# Altium schematic sheet MID_AR0234.SchDoc(U_BC2077__MID_AR0234)
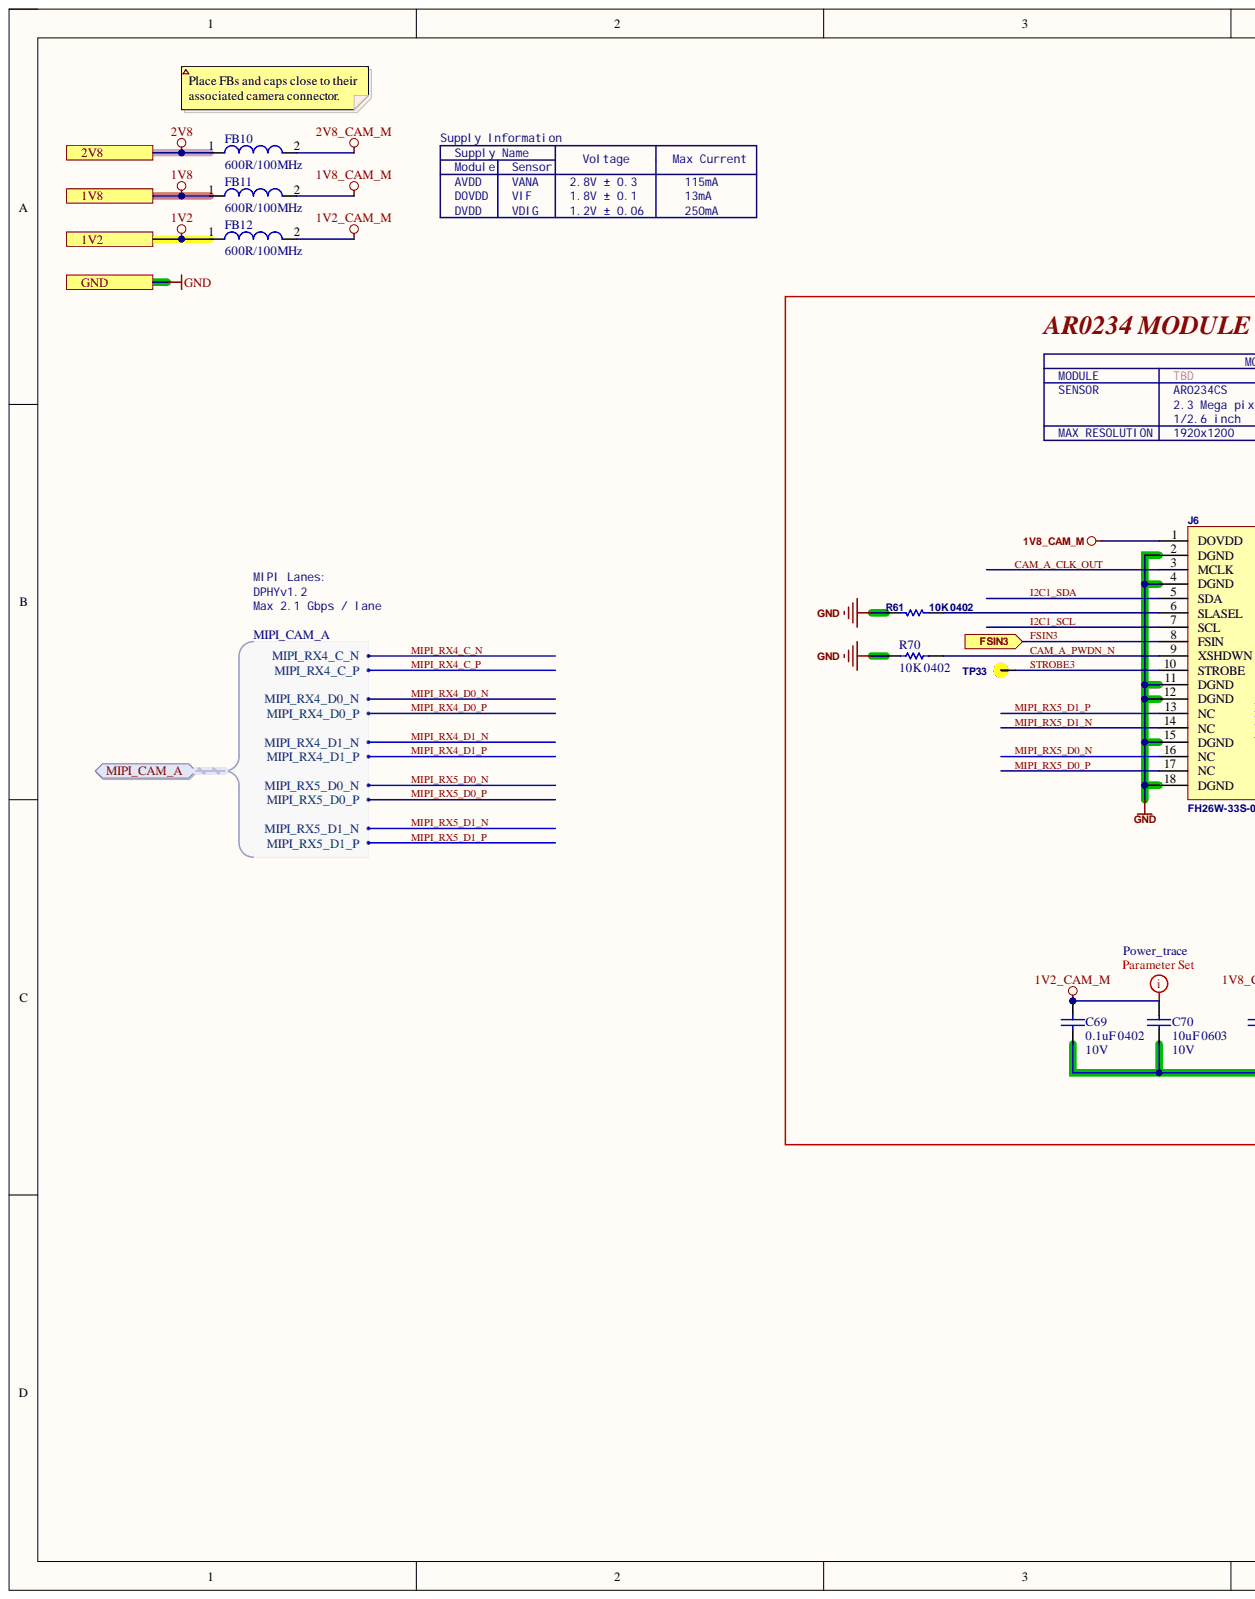
[diagram p0: MID_AR0234.SchDoc, left]
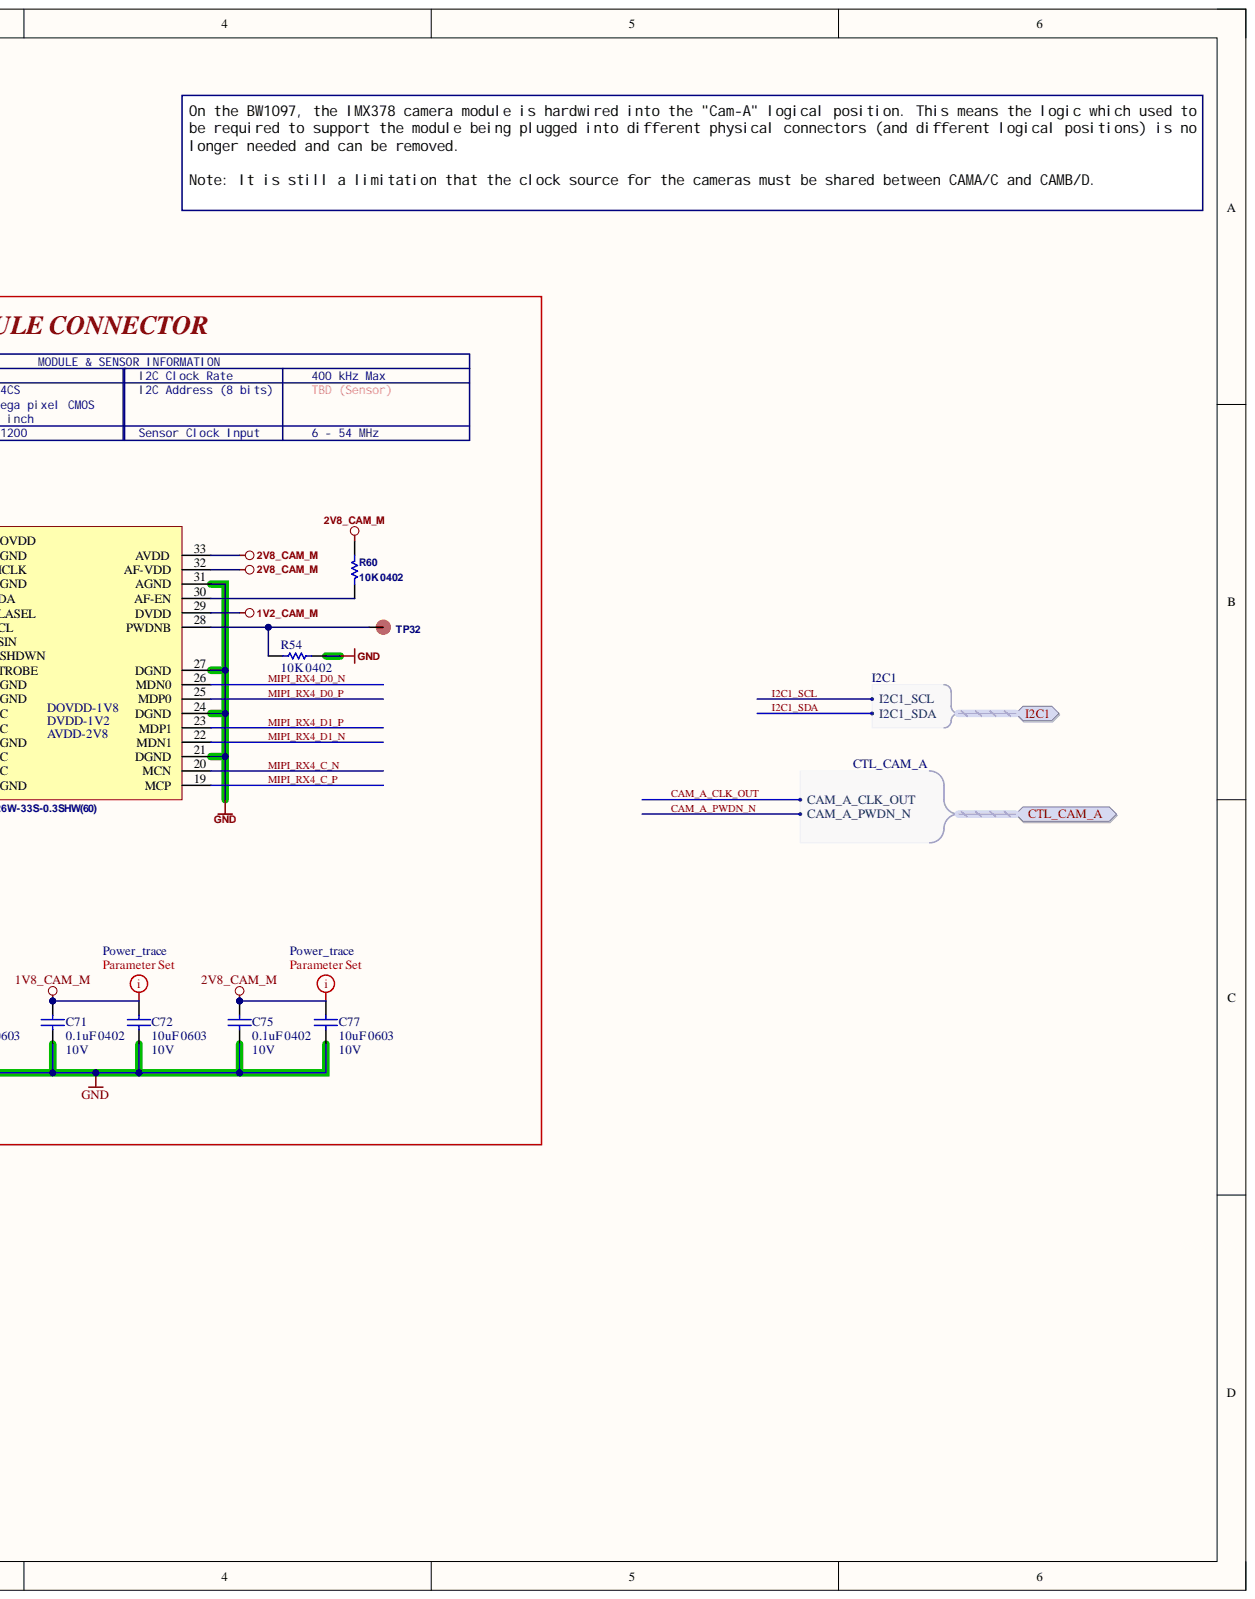
[diagram p1: MID_AR0234.SchDoc, right]

## Parts
C69  0.1uF 0402  Voltage Rating: 10V  [CAPC0402(1005)70_L]
C70  10uF 0603  Capacitance: 10uF  Case Code (Imperial): 0603  Voltage Rating: 10V  [CAPC0603(1608)100_L]
C71  0.1uF 0402  Voltage Rating: 10V  [CAPC0402(1005)70_L]
C72  10uF 0603  Capacitance: 10uF  Case Code (Imperial): 0603  Voltage Rating: 10V  [CAPC0603(1608)100_L]
C75  0.1uF 0402  Voltage Rating: 10V  [CAPC0402(1005)70_L]
C77  10uF 0603  Capacitance: 10uF  Case Code (Imperial): 0603  Voltage Rating: 10V  [CAPC0603(1608)100_L]
FB10  600R/100MHz  [2508056017Y2]
FB11  600R/100MHz  [2508056017Y2]
FB12  600R/100MHz  [2508056017Y2]
J6  FH26W-33S-0.3SHW(60)  [AC-PY003-OV9282]
R54  10K 0402  Case Code (Imperial): 0402  [RESC0402(1005)_L]
R60  10K 0402  Case Code (Imperial): 0402  [RESC0402(1005)_L]
R61  10K 0402  Case Code (Imperial): 0402  [RESC0402(1005)_L]
R70  10K 0402  Case Code (Imperial): 0402  [RESC0402(1005)_L]
TP32  TP100_200_NS  [TP100_200_NS]  (value not drawn)  (no BOM)
TP33  TP100_200_NS  [TP100_200_NS]  (value not drawn)  (no BOM)

## Ports
1V2, 1V8, 2V8, CTL_CAM_A, CTL_CAM_A.CAM_A_CLK_OUT, CTL_CAM_A.CAM_A_PWDN_N, FSIN3, GND, I2C1, I2C1.I2C1_SCL, I2C1.I2C1_SDA, MIPI_CAM_A, MIPI_CAM_A.MIPI_RX4_C_N, MIPI_CAM_A.MIPI_RX4_C_P, MIPI_CAM_A.MIPI_RX4_D0_N, MIPI_CAM_A.MIPI_RX4_D0_P, MIPI_CAM_A.MIPI_RX4_D1_N, MIPI_CAM_A.MIPI_RX4_D1_P, MIPI_CAM_A.MIPI_RX5_D0_N, MIPI_CAM_A.MIPI_RX5_D0_P, MIPI_CAM_A.MIPI_RX5_D1_N, MIPI_CAM_A.MIPI_RX5_D1_P

## Nets
1V2_CAM_M: C69.2 C70.2 FB12.2 J6.29(DVDD)
1V8_CAM_M: C71.2 C72.2 FB11.2 J6.1(DOVDD)
2V8_CAM_M: C75.2 C77.2 FB10.2 J6.32(AF-VDD) J6.33(AVDD) R60.1
1V2: FB12.1 ; port 1V2
1V8: FB11.1 ; port 1V8
2V8: FB10.1 ; port 2V8
CAM_A_CLK_OUT: J6.3(MCLK) ; port CTL_CAM_A
CAM_A_PWDN_N: J6.9(XSHDWN) R70.2 ; port CTL_CAM_A
FSIN3: J6.8(FSIN) ; port FSIN3
GND: C69.1 C70.1 C71.1 C72.1 C75.1 C77.1 J6.2(DGND) J6.4(DGND) J6.11(DGND) J6.12(DGND) J6.15(DGND) J6.18(DGND) J6.21(DGND) J6.24(DGND) J6.27(DGND) J6.31(AGND) R54.2 R61.2 R70.1 ; port GND
I2C1_SCL: J6.7(SCL) ; port I2C1
I2C1_SDA: J6.5(SDA) ; port I2C1
MIPI_RX4_C_N: J6.20(MCN) ; port MIPI_CAM_A
MIPI_RX4_C_P: J6.19(MCP) ; port MIPI_CAM_A
MIPI_RX4_D0_N: J6.26(MDN0) ; port MIPI_CAM_A
MIPI_RX4_D0_P: J6.25(MDP0) ; port MIPI_CAM_A
MIPI_RX4_D1_N: J6.22(MDN1) ; port MIPI_CAM_A
MIPI_RX4_D1_P: J6.23(MDP1) ; port MIPI_CAM_A
MIPI_RX5_D0_N: J6.16(NC) ; port MIPI_CAM_A
MIPI_RX5_D0_P: J6.17(NC) ; port MIPI_CAM_A
MIPI_RX5_D1_N: J6.14(NC) ; port MIPI_CAM_A
MIPI_RX5_D1_P: J6.13(NC) ; port MIPI_CAM_A
STROBE3: J6.10(STROBE) TP33.1
NetJ6_6: J6.6(SLASEL) R61.1
NetJ6_28: J6.28(PWDNB) R54.1 TP32.1
NetJ6_30: J6.30(AF-EN) R60.2

## Library data of the parts
C69 C71 C75 = GRM155R71A104JA01D: CAP CER 0.1UF 10V X7R 0402 5%; Manufacturer 1: Murata; Capacitance: 100nF; Case Code (Imperial): 0402; Case Code (Metric): 1005; Case/Package: 0402; Component Kind: Standard; ComponentLink1Description: https://datasheet.ciiva.com/5411/product-5411135.pdf?src-supplier=Mouser; ComponentLink1URL: https://datasheet.ciiva.com/5411/product-5411135.pdf?src-supplier=Mouser; ComponentLink2Description: https://datasheet.ciiva.com/11618/c02e-11618821.pdf?src-supplier=Avnet; ComponentLink2URL: https://datasheet.ciiva.com/11618/c02e-11618821.pdf?src-supplier=Avnet; Depth: 0.5mm; Dielectric: X7R; Height: 0.5mm; Lead Free: Lead Free; Length: 1mm; Manufacturer Part Number 1: GRM155R71A104JA01D; Max Operating Temperature: 125°C; Min Operating Temperature: -55°C; Mount: Surface Mount; Package Quantity: 10000; Packaging: Tape and Reel; Pricing 1: 1=0.10000, 10=0.02500, 100=0.01130, 500=0.00806, 1000=0.00633, 2500=0.00575, 5000=0.00529 (USD); Radiation Hardening: No; RoHS: Yes; Stock 1: 1346709; Supplier 1: Digi-Key; Supplier Part Number 1: 490-10777-1-ND; Termination: SMD/SMT; Thickness: 0.022inch; Tolerance: 5%; Voltage Rating (DC): 10V; Width: 0.02inch; Library Name: LuxonisMaster.SchLib
C70 C72 C77 = GRM188R61A106ME69D: CAP CER 10UF 10V X5R 0603 20%; Manufacturer 1: Murata; Material: Ceramic; Case Code (Metric): 1608; Case/Package: 0603; Component Kind: Standard; ComponentLink1Description: http://www.mouser.com/ds/2/281/c02e-2905.pdf?src-supplier=Mouser; ComponentLink1URL: http://www.mouser.com/ds/2/281/c02e-2905.pdf?src-supplier=Mouser; ComponentLink2Description: http://components.arrow.com/part/dataview/0323_06119472?src-supplier=Arrow+Europe; ComponentLink2URL: http://components.arrow.com/part/dataview/0323_06119472?src-supplier=Arrow+Europe; ComponentLink3Description: http://www.farnell.com/datasheets/2048014.pdf?src-supplier=Farnell; ComponentLink3URL: http://www.farnell.com/datasheets/2048014.pdf?src-supplier=Farnell; ComponentLink4Description: http://www.farnell.com/datasheets/1872210.pdf?src-supplier=Farnell; ComponentLink4URL: http://www.farnell.com/datasheets/1872210.pdf?src-supplier=Farnell; ComponentLink5Description: http://search.murata.co.jp/Ceramy/image/img/A01X/G101/ENG/GRM188R61A106ME69-01.pdf?src-supplier=Digi-Key; ComponentLink5URL: http://search.murata.co.jp/Ceramy/image/img/A01X/G101/ENG/GRM188R61A106ME69-01.pdf?src-supplier=Digi-Key; ComponentLink6Description: http://www.farnell.com/datasheets/1872210.pdf?src-supplier=Newark; ComponentLink6URL: http://www.farnell.com/datasheets/1872210.pdf?src-supplier=Newark; ComponentLink7Description: http://www.farnell.com/datasheets/2048014.pdf?src-supplier=Element14; ComponentLink7URL: http://www.farnell.com/datasheets/2048014.pdf?src-supplier=Element14; ComponentLink8Description: http://www.farnell.com/datasheets/2048014.pdf?src-supplier=Newark; ComponentLink8URL: http://www.farnell.com/datasheets/2048014.pdf?src-supplier=Newark; Depth: 0.8mm; Dielectric: X5R; Height: 0.8mm; Lead Free: Lead Free; Length: 1.6mm; Manufacturer Part Number 1: GRM188R61A106ME69D; Max Operating Temperature: 85°C; Min Operating Temperature: -55°C; Mount: Surface Mount; Package Quantity: 4000; Packaging: Tape and Reel; Pricing 1: 1=0.21000, 10=0.14100, 100=0.07130, 500=0.04876, 1000=0.04313 (USD); REACH SVHC: Unknown; RoHS: Yes; Stock 1: 2873098; Supplier 1: Digi-Key; Supplier Part Number 1: 490-10475-1-ND; Termination: SMD/SMT; Thickness: 0.035inch; Tolerance: 20%; Voltage Rating (DC): 10V; Weight: 0.000222oz; Width: 0.8mm; Library Name: LuxonisMaster.SchLib
FB10-FB12 = 2508056017Y2: FERRITE BEAD, 0.1OHM, 2A, 0805, 600R/100MHz; Manufacturer 1: Fair-Rite; DC Resistance (DCR): 100mR; Frequency: 100MHz; Impedance: 600R; Resistance: 350mR; Tolerance: 25%; Case Code (Imperial): 0805; Case Code (Metric): 2012; Case/Package: 0805; Component Kind: Standard; ComponentLink1Description: https://datasheet.ciiva.com/3854/3802508056017y2-3854245.pdf?src-supplier=Arrow; ComponentLink1URL: https://datasheet.ciiva.com/3854/3802508056017y2-3854245.pdf?src-supplier=Arrow; ComponentLink2Description: https://datasheet.ciiva.com/22735/652149-22735047.pdf?src-supplier=Element14; ComponentLink2URL: https://datasheet.ciiva.com/22735/652149-22735047.pdf?src-supplier=Element14; ComponentLink3Description: https://datasheet.ciiva.com/1127/26143-1127514.pdf?src-supplier=Farnell; ComponentLink3URL: https://datasheet.ciiva.com/1127/26143-1127514.pdf?src-supplier=Farnell; ComponentLink4Description: https://datasheet.ciiva.com/18090/getdatasheetpartid-212640-18090187.pdf?src-supplier=Verical; ComponentLink4URL: https://datasheet.ciiva.com/18090/getdatasheetpartid-212640-18090187.pdf?src-supplier=Verical; ComponentLink5Description: https://datasheet.ciiva.com/7620/26143-7620140.pdf?src-supplier=Element14; ComponentLink5URL: https://datasheet.ciiva.com/7620/26143-7620140.pdf?src-supplier=Element14; Current Rating: 2A; DC Current: 2A; Depth: 1.25mm; Height: 0.9mm; Length: 2mm; Manufacturer Part Number 1: 2508056017Y2; Max DC Current: 2A; Max Operating Temperature: 125°C; Military Standard: Not; Min Operating Temperature: -55°C; Number of Pins: 2; Package Quantity: 4000; Packaging: Tape and Reel; Pricing 1: 1=0.10000, 10=0.07900, 25=0.07120, 50=0.05240, 100=0.04520, 250=0.03568, 500=0.03014, 1000=0.02538 (USD); Radiation Hardening: No; REACH SVHC: No SVHC; RoHS: Yes; Stock 1: 100491; Supplier 1: Digi-Key; Supplier Part Number 1: 1934-1487-1-ND; Termination: SMD/SMT; Test Frequency: 100MHz; Width: 1.25mm; Library Name: LuxonisMaster.SchLib
J6 = AC_PY003-OV9282_CON: FFC/FPC Connector, 33 Contact(s), 1 Row(s), Female, Right Angle, Surface Mount Terminal; Manufacturer 1: Hirose; Category: FFC / FPC; Component Kind: Standard; ComponentLink1Description: https://datasheet.ciiva.com:443/pdfs/VipMasterIC/IC/HIRO/HIRO-S-A0007913874/HIRO-S-A0008593114-1.pdf?src-supplier=IHS+Markit; ComponentLink1URL: https://datasheet.ciiva.com:443/pdfs/VipMasterIC/IC/HIRO/HIRO-S-A0007913874/HIRO-S-A0008593114-1.pdf?src-supplier=IHS+Markit; ComponentLink2Description: https://www.hirose.com/product/en/download_file/key_name/FH26/category/Catalog/doc_file_id/49355/?file_category_id=4&item_id=298&is_series=1&src-supplier=Digi-Key; ComponentLink2URL: https://www.hirose.com/product/en/download_file/key_name/FH26/category/Catalog/doc_file_id/49355/?file_category_id=4&item_id=298&is_series=1&src-supplier=Digi-Key; ComponentLink3Description: https://www.hirose.com/product/download/?distributor=digikey&amp;type=2d&amp;lang=en&amp;num=FH26W-13S-0.3SHW(60)&src-supplier=Digi-Key; ComponentLink3URL: https://www.hirose.com/product/download/?distributor=digikey&amp;type=2d&amp;lang=en&amp;num=FH26W-13S-0.3SHW(60)&src-supplier=Digi-Key; Library Name: DM9098.SchLib; Manufacturer Part Number 1: FH26W-33S-0.3SHW(60); Pricing 1: 1=1,78000, 10=1,61700, 25=1,51800, 50=1,45200, 100=1,38600, 250=1,25400, 500=1,15500, 1000=0,99000, 2500=0,92400 (USD); RoHS: Yes; Stock 1: 627; Supplier 1: Digi-Key; Supplier Part Number 1: H125800CT-ND
R54 R60 R61 R70 = RC0402FR-1310KL: RES SMD 10K OHM 1% 0402 1/16W Chip Resistor, 0402 [1005 Metric], 10 kohm, RC Series, 50 V, Thick Film, 62.5 mW; Manufacturer 1: Yageo; Power Rating: 62.5mW; Resistance: 10kR; Temperature Coefficient: 100ppm/°C; Voltage Rating: 50V; Case Code (Metric): 1005; Case/Package: 0402; Component Kind: Standard; ComponentLink1Description: https://datasheet.ciiva.com/16940/pyu-rc0402-51-rohs-l-6-16940293.pdf?src-supplier=Avnet+Europe; ComponentLink1URL: https://datasheet.ciiva.com/16940/pyu-rc0402-51-rohs-l-6-16940293.pdf?src-supplier=Avnet+Europe; ComponentLink2Description: https://datasheet.ciiva.com/28386/pyu-rc0402-51-rohs-l-6-28386855.pdf?src-supplier=Avnet+Europe; ComponentLink2URL: https://datasheet.ciiva.com/28386/pyu-rc0402-51-rohs-l-6-28386855.pdf?src-supplier=Avnet+Europe; ComponentLink3Description: https://datasheet.ciiva.com/16740/pyu-rc0402-51-rohs-l-6-r-16740602.pdf?src-supplier=Future+Electronics; ComponentLink3URL: https://datasheet.ciiva.com/16740/pyu-rc0402-51-rohs-l-6-r-16740602.pdf?src-supplier=Future+Electronics; ComponentLink4Description: https://datasheet.ciiva.com/8891/pyu-rc0402-51-rohs-l-6-8891339.pdf?src-supplier=Avnet; ComponentLink4URL: https://datasheet.ciiva.com/8891/pyu-rc0402-51-rohs-l-6-8891339.pdf?src-supplier=Avnet; ComponentLink5Description: https://datasheet.ciiva.com/5557/pyu-rc0402-51-rohs-l-6-r-5557997.pdf?src-supplier=Mouser; ComponentLink5URL: https://datasheet.ciiva.com/5557/pyu-rc0402-51-rohs-l-6-r-5557997.pdf?src-supplier=Mouser; ComponentLink6Description: https://datasheet.ciiva.com/7983/1018594-7983533.pdf?src-supplier=Element14; ComponentLink6URL: https://datasheet.ciiva.com/7983/1018594-7983533.pdf?src-supplier=Element14; Composition: Thick Film; Contact Plating: Tin,Matte,Lead; Depth: 0.5mm; Lead Free: Lead Free; Length: 1mm; Manufacturer Part Number 1: RC0402FR-1310KL; Max Operating Temperature: 155°C; Military Standard: Not; Min Operating Temperature: -55°C; Number of Pins: 2; Number of Terminations: 2; Packaging: Tape and Reel; Pricing 1: 1=0.10000, 25=0.01200, 100=0.00400, 250=0.00400, 500=0.00300, 1000=0.00300 (USD); Radiation Hardening: No; REACH SVHC: No SVHC; RoHS: Yes; Stock 1: 62239; Supplier 1: Newark; Supplier 2: Future Electronics; Supplier 3: Mouser; Supplier 4: Element14; Supplier Part Number 1: 67R9960; Supplier Part Number 2: RC0402FR-1310KL-CUTT; Supplier Part Number 3: 603-RC0402FR-1310KL; Supplier Part Number 4: 1799235; Termination: SMD/SMT; Tolerance: 1%; Voltage Rating (DC): 50V; Working Voltage: 50V; Library Name: LuxonisMaster.SchLib
TP32 TP33 = TEST_POINT: Library Name: LuxonisMaster.SchLib
